# Altium schematic sheet BG96.SchDoc
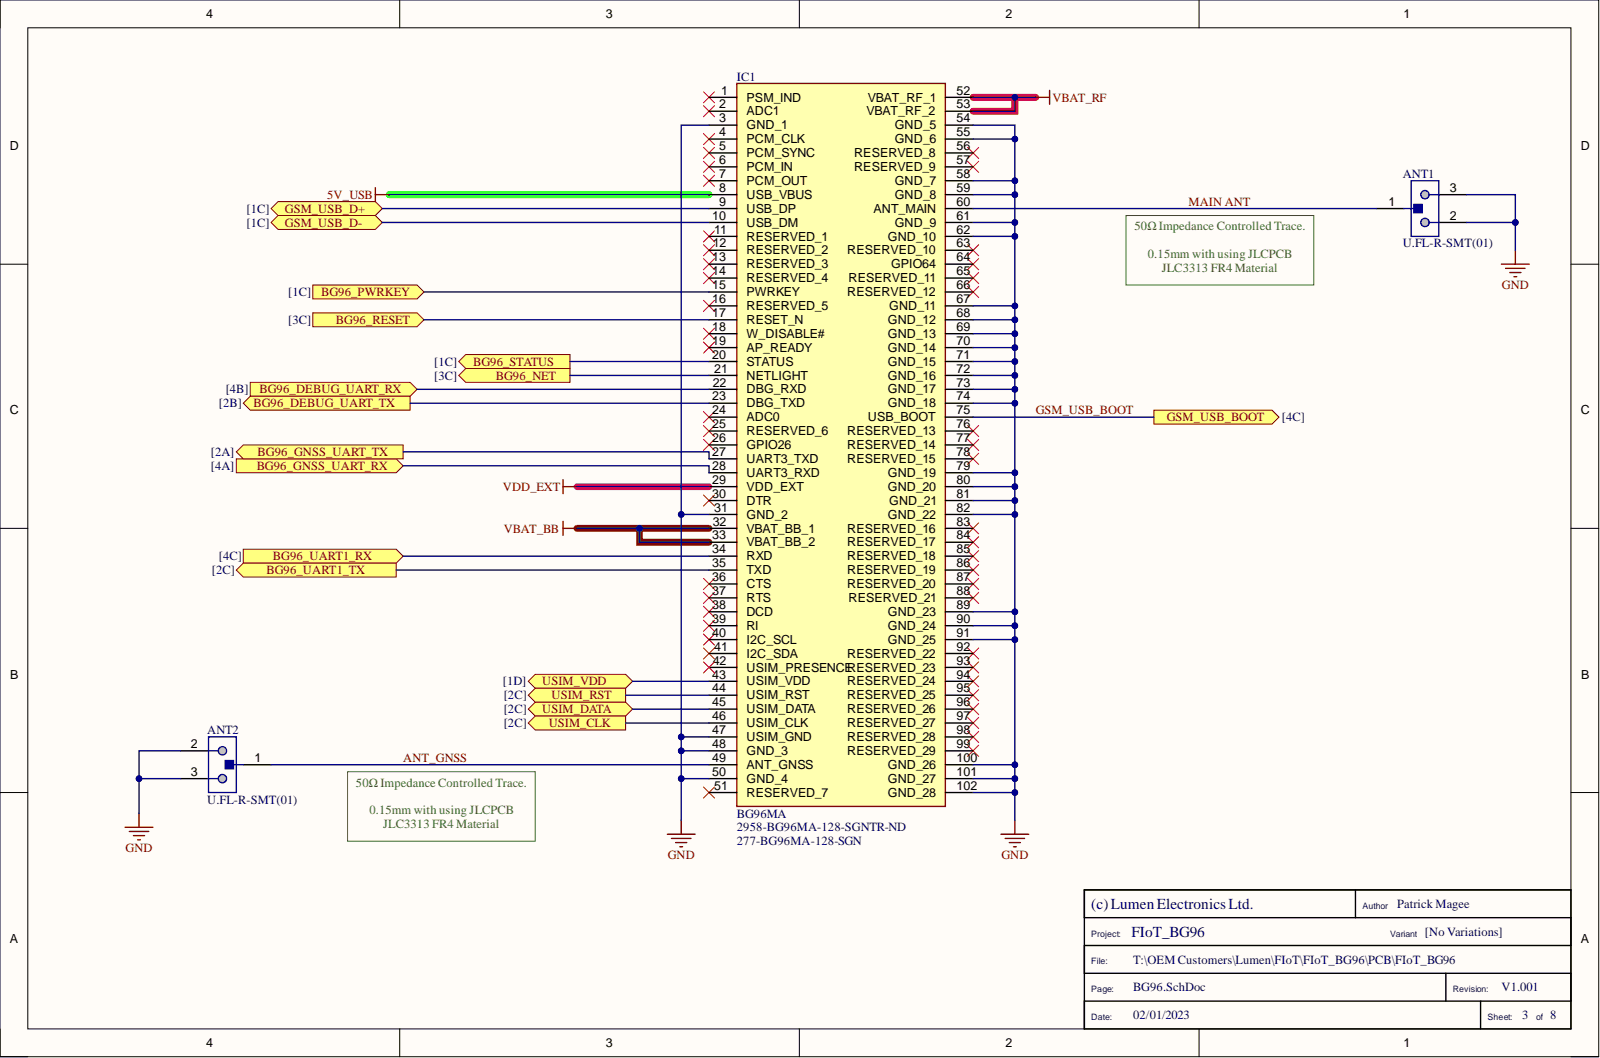
[diagram p0: BG96.SchDoc]

## Parts
ANT1  U.FL-R-SMT(01)  [HRS-U.FL-R-SMT(10)_V]
ANT2  U.FL-R-SMT(01)  [HRS-U.FL-R-SMT(10)_V]
IC1  BG96MA  Supplier Part Number 1: 2958-BG96MA-128-SGNTR-ND  [BG96MA]

## Ports
BG96_DEBUG_UART_RX, BG96_DEBUG_UART_TX, BG96_GNSS_UART_RX, BG96_GNSS_UART_TX, BG96_NET, BG96_PWRKEY, BG96_RESET, BG96_STATUS, BG96_UART1_RX, BG96_UART1_TX, GSM_USB_BOOT, GSM_USB_D+, GSM_USB_D-, USIM_CLK, USIM_DATA, USIM_RST, USIM_VDD

## Nets
5V_USB: IC1.8(USB_VBUS)
GND: ANT1.2 ANT1.3 ANT2.2 ANT2.3 IC1.3(GND_1) IC1.31(GND_2) IC1.47(USIM_GND) IC1.48(GND_3) IC1.50(GND_4) IC1.54(GND_5) IC1.55(GND_6) IC1.58(GND_7) IC1.59(GND_8) IC1.61(GND_9) IC1.62(GND_10) IC1.67(GND_11) IC1.68(GND_12) IC1.69(GND_13) IC1.70(GND_14) IC1.71(GND_15) IC1.72(GND_16) IC1.73(GND_17) IC1.74(GND_18) IC1.79(GND_19) IC1.80(GND_20) IC1.81(GND_21) IC1.82(GND_22) IC1.89(GND_23) IC1.90(GND_24) IC1.91(GND_25) IC1.100(GND_26) IC1.101(GND_27) IC1.102(GND_28)
VBAT_BB: IC1.32(VBAT_BB_1) IC1.33(VBAT_BB_2)
VBAT_RF: IC1.52(VBAT_RF_1) IC1.53(VBAT_RF_2)
VDD_EXT: IC1.29(VDD_EXT)
ANT_GNSS: ANT2.1 IC1.49(ANT_GNSS)
BG96_DEBUG_UART_RX: IC1.22(DBG_RXD) ; port BG96_DEBUG_UART_RX
BG96_DEBUG_UART_TX: IC1.23(DBG_TXD) ; port BG96_DEBUG_UART_TX
BG96_GNSS_UART_RX: IC1.28(UART3_RXD) ; port BG96_GNSS_UART_RX
BG96_GNSS_UART_TX: IC1.27(UART3_TXD) ; port BG96_GNSS_UART_TX
BG96_NET: IC1.21(NETLIGHT) ; port BG96_NET
BG96_PWRKEY: IC1.15(PWRKEY) ; port BG96_PWRKEY
BG96_RESET: IC1.17(RESET_N) ; port BG96_RESET
BG96_STATUS: IC1.20(STATUS) ; port BG96_STATUS
BG96_UART1_RX: IC1.34(RXD) ; port BG96_UART1_RX
BG96_UART1_TX: IC1.35(TXD) ; port BG96_UART1_TX
GSM_USB_BOOT: IC1.75(USB_BOOT) ; port GSM_USB_BOOT
GSM_USB_D+: IC1.9(USB_DP) ; port GSM_USB_D+
GSM_USB_D-: IC1.10(USB_DM) ; port GSM_USB_D-
MAIN ANT: ANT1.1 IC1.60(ANT_MAIN)
USIM_CLK: IC1.46(USIM_CLK) ; port USIM_CLK
USIM_DATA: IC1.45(USIM_DATA) ; port USIM_DATA
USIM_RST: IC1.44(USIM_RST) ; port USIM_RST
USIM_VDD: IC1.43(USIM_VDD) ; port USIM_VDD

Not connected: IC1 1(PSM_IND) 2(ADC1) 4(PCM_CLK) 5(PCM_SYNC) 6(PCM_IN) 7(PCM_OUT) 11(RESERVED_1) 12(RESERVED_2) 13(RESERVED_3) 14(RESERVED_4) 16(RESERVED_5) 18(W_DISABLE#) 19(AP_READY) 24(ADC0) 25(RESERVED_6) 26(GPIO26) 30(DTR) 36(CTS) 37(RTS) 38(DCD) 39(RI) 40(I2C_SCL) 41(I2C_SDA) 42(USIM_PRESENCE) 51(RESERVED_7) 56(RESERVED_8) 57(RESERVED_9) 63(RESERVED_10) 64(GPIO64) 65(RESERVED_11) 66(RESERVED_12) 76(RESERVED_13) 77(RESERVED_14) 78(RESERVED_15) 83(RESERVED_16) 84(RESERVED_17) 85(RESERVED_18) 86(RESERVED_19) 87(RESERVED_20) 88(RESERVED_21) 92(RESERVED_22) 93(RESERVED_23) 94(RESERVED_24) 95(RESERVED_25) 96(RESERVED_26) 97(RESERVED_27) 98(RESERVED_28) 99(RESERVED_29)

## Library data of the parts
ANT1 ANT2 = U.FL-R-SMT(01): CONN RECPT ULTRA-MINI COAX SMD; Manufacturer: Hirose Electric; Approval Category: UL94V-0; Body Plating: Silver; Body Style: StraightJack; Centre Contact Resistance: 25mohm; Coaxial Termination: Solder; Connector Mounting: PCB; Connector Mounting Orientation: PCBoard; Connector Type: UFLCoaxial; Contact Resistance: 10mohm; External Length / Height: 2.5mm; Female Contact Material: PhosphorBronze; Female Contact Plating: Gold; Frequency Max: 6GHz; Impedance: 50ohm; Insulation Resistance: 500Mohm; Jacket Material: LCP; Male Contact Material: Brass; MFG Name: Hirose; Secondary Insulation Material: PBT; SVHC: NoSVHC(18-Jun-2012); Voltage Rating V AC: 60V; VSWR: 1.3; Component Kind: Standard; Contact Material: PhosphorBronze; Contact Plating: Gold; Datasheet URL: http://www.farnell.com/datasheets/91524.pdf; Datasheet Version: Feb-04; Flammability Rating: UL94V-0; JLC Footprint: ANT-SMD; JLCPCB Part #: C434812; Library Name: FIoT_BG96.SCHLIB; Male Contact Plating: Gold; Manufacturer Part Number: U.FL-R-SMT(01); Manufacturer URL: https://www.hirose.com; Material: PhosphorBronze; MFG Part Number: U.FL-R-SMT(01); Mounting Type: PCBoard; Operating Frequency Max: 6GHz; Operating Temperature Max: 90degC; Operating Temperature Min: -40degC; Outer Contact Resistance: 10mohm; Package Description: 3-Pin Surface Mount Device, Body 2.6 x 2.6 mm; Package Reference: U.FL-R-SMT(10); Supplier 1: Farnell; Supplier 2: Digi-Key; Supplier 3: Mouser; Supplier Part Number 1: 3908021; Supplier Part Number 2: H9161-ND; Supplier Part Number 3: 798-U.FL-R-SMT01; Termination Method: Solder; Value: U.FL-R-SMT(01)
IC1 = BG96MA: Cellular EDGE, GPRS, GSM, HSPA, LTE, UMTS Transceiver Module 850MHz, 900MHz, 1.8GHz, 1.9GHz Antenna Not Included Surface Mount; Manufacturer_Name: Quectel; Component Kind: Standard; Datasheet Link: http://www.quectel.com/product/bg96.htm; Height: 2mm; JLC Footprint: Not available with JLC; JLCPCB Part #: Not available with JLC; Library Name: FIoT_BG96.SCHLIB; Manufacturer_Part_Number: BG96MA; MFG Name: Quectel; MFG Part Number: BG96MA; Supplier 1: Digi-Key; Supplier 2: Mouser; Supplier Part Number 2: 277-BG96MA-128-SGN
